# Altium schematic sheet LED ChaserSch.SchDoc("LED ChaserSch")
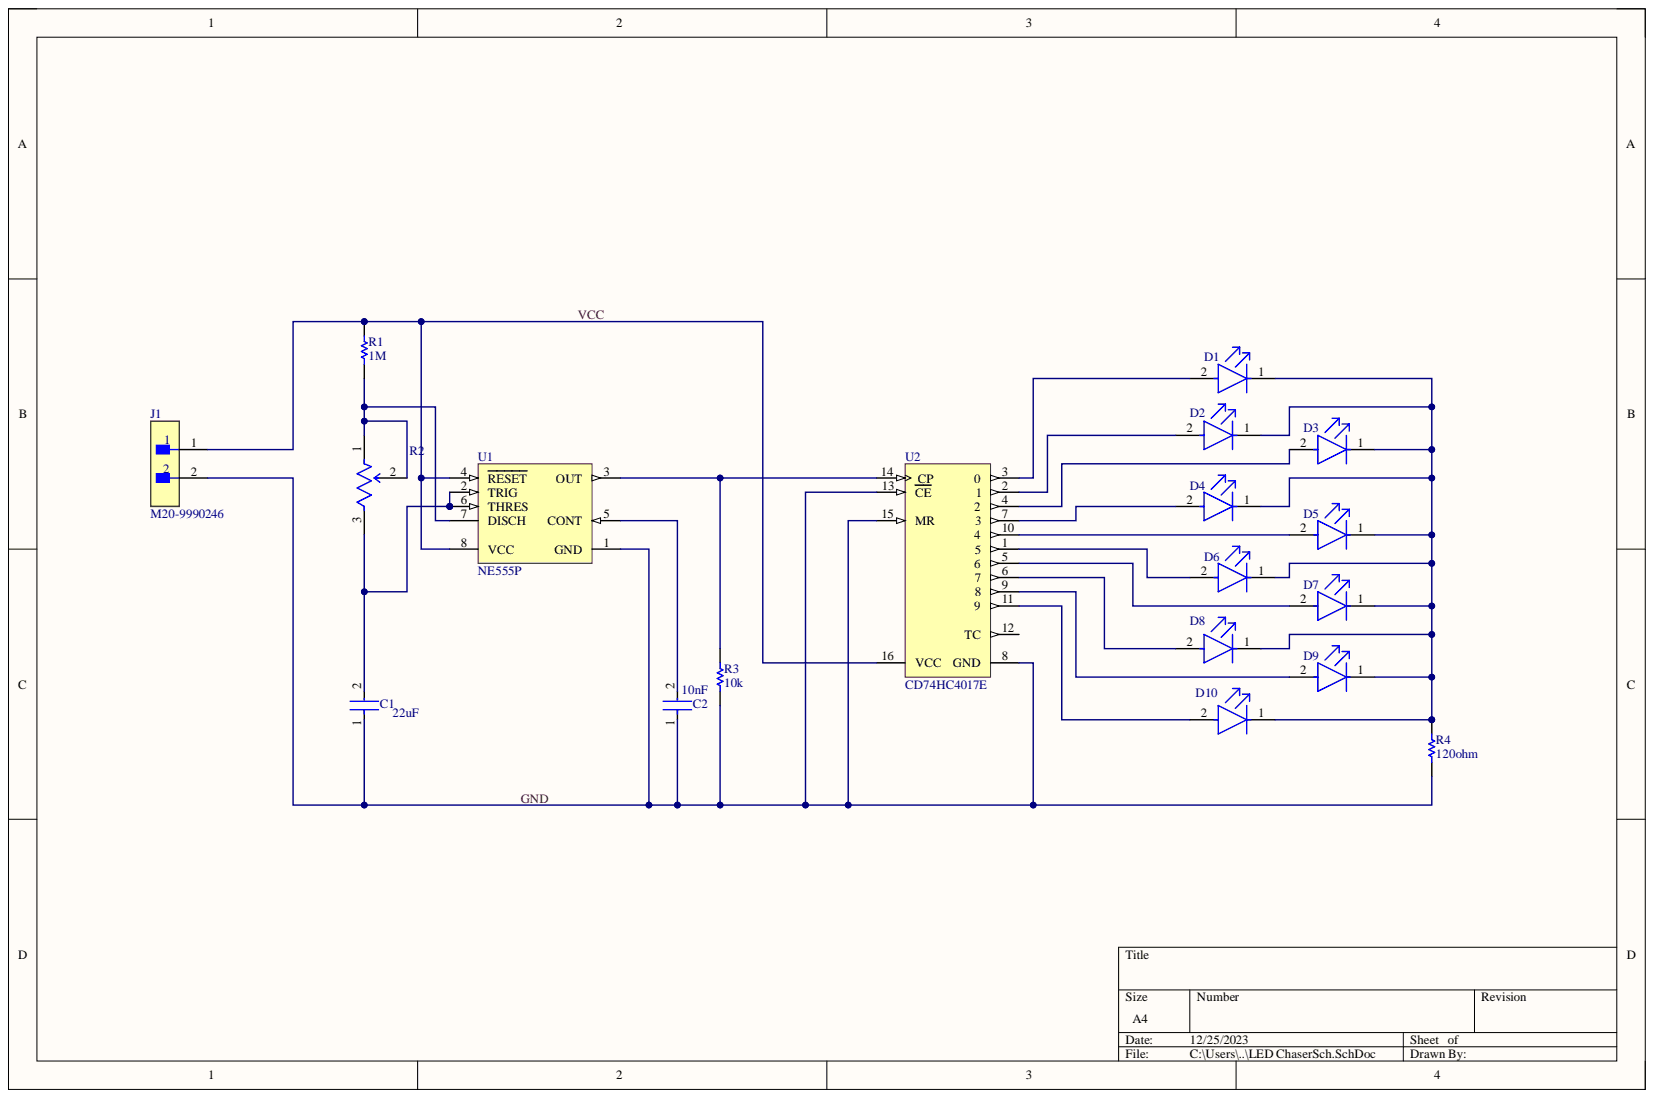
[diagram p0: LED ChaserSch.SchDoc]

## Parts
C1  Capacitance: 22uF  [FP-RCE3DB-MFG]  (hidden comment: RCER71E106K3DBH03A)
C2  Capacitance: 10nF  [FP-RCE3DB-MFG]  (hidden comment: RCER71E106K3DBH03A)
D1  LTST-C190GKT  [FP-LTST-C190GKT-MFG]  (value not drawn)
D2  LTST-C190GKT  [FP-LTST-C190GKT-MFG]  (value not drawn)
D3  LTST-C190GKT  [FP-LTST-C190GKT-MFG]  (value not drawn)
D4  LTST-C190GKT  [FP-LTST-C190GKT-MFG]  (value not drawn)
D5  LTST-C190GKT  [FP-LTST-C190GKT-MFG]  (value not drawn)
D6  LTST-C190GKT  [FP-LTST-C190GKT-MFG]  (value not drawn)
D7  LTST-C190GKT  [FP-LTST-C190GKT-MFG]  (value not drawn)
D8  LTST-C190GKT  [FP-LTST-C190GKT-MFG]  (value not drawn)
D9  LTST-C190GKT  [FP-LTST-C190GKT-MFG]  (value not drawn)
D10  LTST-C190GKT  [FP-LTST-C190GKT-MFG]  (value not drawn)
J1  M20-9990246  [FP-M20-9990246-MFG]
R1  1M  [OMTE-RES7X2.5-2]
R2  3312J-1-504E  [FP-3312-MFG]  (value not drawn)
R3  10k  [OMTE-RES7X2.5-2]
R4  120ohm  [OMTE-RES7X2.5-2]
U1  NE555P  [P0008A]
U2  CD74HC4017E  [N0016A]

## Nets
GND: C1.1 C2.1 J1.2 R3.1 R4.1 U1.1(GND) U2.8(GND) U2.13(CE) U2.15(MR)
VCC: J1.1 R1.2 U1.4(RESET) U1.8(VCC) U2.16(VCC)
NetC1_2: C1.2 R2.3 U1.2(TRIG) U1.6(THRES)
NetC2_2: C2.2 U1.5(CONT)
NetD1_1: D1.1 D2.1 D3.1 D4.1 D5.1 D6.1 D7.1 D8.1 D9.1 D10.1 R4.2
NetD1_2: D1.2 U2.3
NetD2_2: D2.2 U2.2
NetD3_2: D3.2 U2.4
NetD4_2: D4.2 U2.7
NetD5_2: D5.2 U2.10
NetD6_2: D6.2 U2.1
NetD7_2: D7.2 U2.5
NetD8_2: D8.2 U2.6
NetD9_2: D9.2 U2.9
NetD10_2: D10.2 U2.11
NetR1_1: R1.1 R2.1 R2.2 U1.7(DISCH)
NetR3_2: R3.2 U1.3(OUT) U2.14(CP)

Not connected: U2 12(TC)

## Library data of the parts
C1 C2 = CMP-06035-005830-1: Cap Ceramic 10uF 25V X7R ±10% Radial 2.5mm +125°C Automotive Ammo Pack; Lead Diameter: 0.5mm; Lead/Base Style: Straight; Case/Package: Radial; Component Kind: Standard; Dielectric: X7R; Height: 5mm; Height - Seated (Max): 0.197inch; Lead Pitch: 0.098inch; Length: 0.217inch; Library Name: Altium Content Vault; Max Operating Temperature: 125°C; Min Operating Temperature: -55°C; Mount: Through Hole; Package Quantity: 1500; Packaging: Tape and Reel; Ratings: AEC-Q200; Resistor Type: Ceramic; Termination: Radial; Tolerance: 10%; Voltage Rating: 25V; Voltage Rating (DC): 25V; Width: 0.157inch
D1-D10 = CMP-22017-000005-2: LED GREEN CLEAR CHIP SMD; Dominant Wavelength: 569nm; Forward Current: 30mA; Forward Voltage: 2.1V; Lens Color: Colorless; Luminous Intensity: 6mcd; Number of Elements: 1; Power Dissipation: 100mW; Reverse Voltage: 5V; Test Current: 10mA; Viewing Angle: 130°; Voltage: 2V; Wavelength: 565nm; Case Code (Imperial): 0603; Case Code (Metric): 1608; Case/Package: 0603; Color: Green; Component Kind: Standard; Depth: 0.8mm; Height: 0.8mm; Illumination Color: Green; Lead Free: Lead Free; Length: 1.6mm; Lens Style: Clear; Lens Transparency: Clear; Library Name: Altium Content Vault; Max Operating Temperature: 85°C; Min Operating Temperature: -55°C; Mount: Surface Mount; Number of LEDs: 1; Number of Pins: 2; Package Quantity: 1; Packaging: Tape and Reel; Power Rating: 100mW; Radiation Hardening: No; Width: 0.8mm
J1 = CMP-15831-000009-1: Connector Header Through Hole 2 position 0.100" (2.54mm); Manufacturer: Harwin; Conn Gender: Male; Conn Orientation: Vertical; Current Rating: 3A; Number Of Contacts: 2; Automotive: No; Category: Conn; Component Kind: Standard; ComponentLink2Description: Datasheet; ComponentLink2URL: https://cdn.harwin.com/pdfs/M20-999.pdf; Contact Material: Tin,Nickel; Device Class L1: Connectors; Device Class L2: Headers and Wire Housings; Device Class L3: unset; Digikey Description: CONN HEADER VERT 2POS 2.54MM; Height: 8.99mm; Lead Free: Yes; Library Name: Altium Content Vault; Manufacturer Part Number: M20-9990246; Mouser Description: 2.54mm (0.1") Pitch SIL Vertical PC Tail Pin Header, 6.1mm mating pin height, tin, 2 contacts; Number Of Rows: 1; Octopart: https://octopart.com/m20-9990246-harwin-2590635; Package: M20-9990246; Pitch: 2.54mm; RoHS: TRUE; Temperature: -40°C to +105°C; Temperature Range High: +105°C; Temperature Range Low: -40°C
R1 = CMP-2000-06865-1: Little Demon(R) Carbon Composition Molded OD Series, 250 V, 10 KOhm, +/-5%, -70 to 130 degC, 2-Pin THD, RoHS, Bulk; Manufacturer: Ohmite; PartNumber: OD103JE; Catalog Drawings: OD,OF,OASeries; EDA / CAD Models: DownloadfromAcceleratedDesigns; Online Catalog: OD,OF,OASeries,LittleDemon®; Power (Watts): 0.25W,1/4W; Power Rating: 0.25W; Resistance (Ohms): 10M; Tolerance: ±5%; Category: Resistors; Component Kind: Standard; Composition: CarbonComposition; Datasheet URL: http://www.ohmite.com/cat/res_od_of_oa.pdf; Datasheet Version: 06/2016; Family: ThroughHoleResistors; Features: PulseWithstanding; Height: -; Library Name: Altium Content Vault; Manufacturer URL: http://www.ohmite.com/; Mounting Technology: ThroughHole; Number of Terminations: 2; Operating Temperature: -; Package / Case: Axial; Package Description: 2-Pin THD Resistor, Body 7 x 2.5 mm, Lead Dia. 0.6 mm; Package Reference: RES7X2.5; Packaging: Bulk; RoHS Information: LittleDemon"E"SeriesMaterialDeclaration; Series: LittleDemon®OD; Size / Dimension: 0.098"Diax0.276"L(2.50mmx7.00mm); Standard Package: 100; Supplier Device Package: Axial; Temperature Coefficient: -
R2 = CMP-07234-000022-1: TRIMMER 500K OHM 0.1W J LEAD TOP; Insulation Resistance: 500MR; Max Power Dissipation: 100mW; Number of Turns: 1; Resistance: 50kR; Temperature Coefficient: 100ppm/°C; Tolerance: 20%; Voltage Rating: 500V; Component Kind: Standard; Composition: Cermet; Depth: 2.8mm; Height: 0.067inch; Length: 2.5mm; Library Name: Altium Content Vault; Max Operating Temperature: 125°C; Min Operating Temperature: -55°C; Mount: Surface Mount; Package Quantity: 1; Packaging: Tape and Reel; Power Rating: 100mW; REACH SVHC: No SVHC; Termination: J-Hook; Voltage Rating (DC): 500V; Weight: 0.0321g; Width: 2.5mm
R3 = CMP-2000-06865-1: Little Demon(R) Carbon Composition Molded OD Series, 250 V, 10 KOhm, +/-5%, -70 to 130 degC, 2-Pin THD, RoHS, Bulk; Manufacturer: Ohmite; PartNumber: OD103JE; Catalog Drawings: OD,OF,OASeries; EDA / CAD Models: DownloadfromAcceleratedDesigns; Online Catalog: OD,OF,OASeries,LittleDemon®; Power (Watts): 0.25W,1/4W; Power Rating: 0.25W; Tolerance: ±5%; Category: Resistors; Component Kind: Standard; Composition: CarbonComposition; Datasheet URL: http://www.ohmite.com/cat/res_od_of_oa.pdf; Datasheet Version: 06/2016; Family: ThroughHoleResistors; Features: PulseWithstanding; Height: -; Library Name: Altium Content Vault; Manufacturer URL: http://www.ohmite.com/; Mounting Technology: ThroughHole; Number of Terminations: 2; Operating Temperature: -; Package / Case: Axial; Package Description: 2-Pin THD Resistor, Body 7 x 2.5 mm, Lead Dia. 0.6 mm; Package Reference: RES7X2.5; Packaging: Bulk; Resistance (Ohms): 10k; RoHS Information: LittleDemon"E"SeriesMaterialDeclaration; Series: LittleDemon®OD; Size / Dimension: 0.098"Diax0.276"L(2.50mmx7.00mm); Standard Package: 100; Supplier Device Package: Axial; Temperature Coefficient: -
R4 = CMP-2000-06865-1: Little Demon(R) Carbon Composition Molded OD Series, 250 V, 10 KOhm, +/-5%, -70 to 130 degC, 2-Pin THD, RoHS, Bulk; Manufacturer: Ohmite; PartNumber: OD103JE; Catalog Drawings: OD,OF,OASeries; EDA / CAD Models: DownloadfromAcceleratedDesigns; Online Catalog: OD,OF,OASeries,LittleDemon®; Power (Watts): 0.25W,1/4W; Power Rating: 0.25W; Resistance (Ohms): 10k; Tolerance: ±5%; Category: Resistors; Component Kind: Standard; Composition: CarbonComposition; Datasheet URL: http://www.ohmite.com/cat/res_od_of_oa.pdf; Datasheet Version: 06/2016; Family: ThroughHoleResistors; Features: PulseWithstanding; Height: -; Library Name: Altium Content Vault; Manufacturer URL: http://www.ohmite.com/; Mounting Technology: ThroughHole; Number of Terminations: 2; Operating Temperature: -; Package / Case: Axial; Package Description: 2-Pin THD Resistor, Body 7 x 2.5 mm, Lead Dia. 0.6 mm; Package Reference: RES7X2.5; Packaging: Bulk; RoHS Information: LittleDemon"E"SeriesMaterialDeclaration; Series: LittleDemon®OD; Size / Dimension: 0.098"Diax0.276"L(2.50mmx7.00mm); Standard Package: 100; Supplier Device Package: Axial; Temperature Coefficient: -
U1 = CMP-2000-04954-1: Precision Timer, 4.5 to 16 V, 0 to 70 degC, 8-Pin DIP, Pb-Free, Tube; Manufacturer: TexasInstruments; Maximum High Level Output Current: -200mA; Maximum Low Level Output Current: 200mA; Number of Internal Timers: 1; Operating Supply Voltage: 5; Rad Hard: No; Category: Timer; Component Kind: Standard; Datasheet URL: http://www.ti.com/general/docs/lit/getliterature.tsp?genericPartNumber=NE555&fileType=pdf; Datasheet Version: Rev. I, 09/2014; Library Name: Altium Content Vault; Manufacturer URL: http://www.ti.com/; Package: 8PDIP; Package Description: 8-Pin Double In-line Package, Body 9.59 x 6.35 mm, Pitch 2.54 mm; Package Reference: P8; Package URL: http://www.ti.com/lit/ml/mpdi001b/mpdi001b.pdf; Packaging: Rail/Tube; Type: Standard
U2 = CMP-1623-00548-2: High Speed CMOS Logic Decade Counter/Divider with 10 Decoded Outputs, N0016A, TUBE; Manufacturer: Texas Instruments; Bits(#): 10; F @ Nom Voltage(Max)(Mhz): 28; ICC @ Nom Voltage(Max)(mA): 0.08; Input Type: LVTTL/CMOS; Inputs: 1; Output Drive (IOL/IOH)(Max)(mA): 5.2/-5.2; Rating: Catalog; Schmitt Trigger: No; Technology Family: HC; tpd @ Nom Voltage(Max)(ns): 54; VCC(Max)(V): 6; VCC(Min)(V): 2; Voltage(Nom)(V): 3.3,5; Code_JEDEC: MS-001; Component Kind: Standard; DatasheetVersion: SCHS200D; Function: Counter; Generic Part Number: CD74HC4017; Library Name: Altium Content Vault; Operating Temperature Range(C): -55 to 125; Output Type: CMOS; PackageReference: N0016A; Type: Decade
